# Altium schematic sheet TOP.SchDoc(TOP)
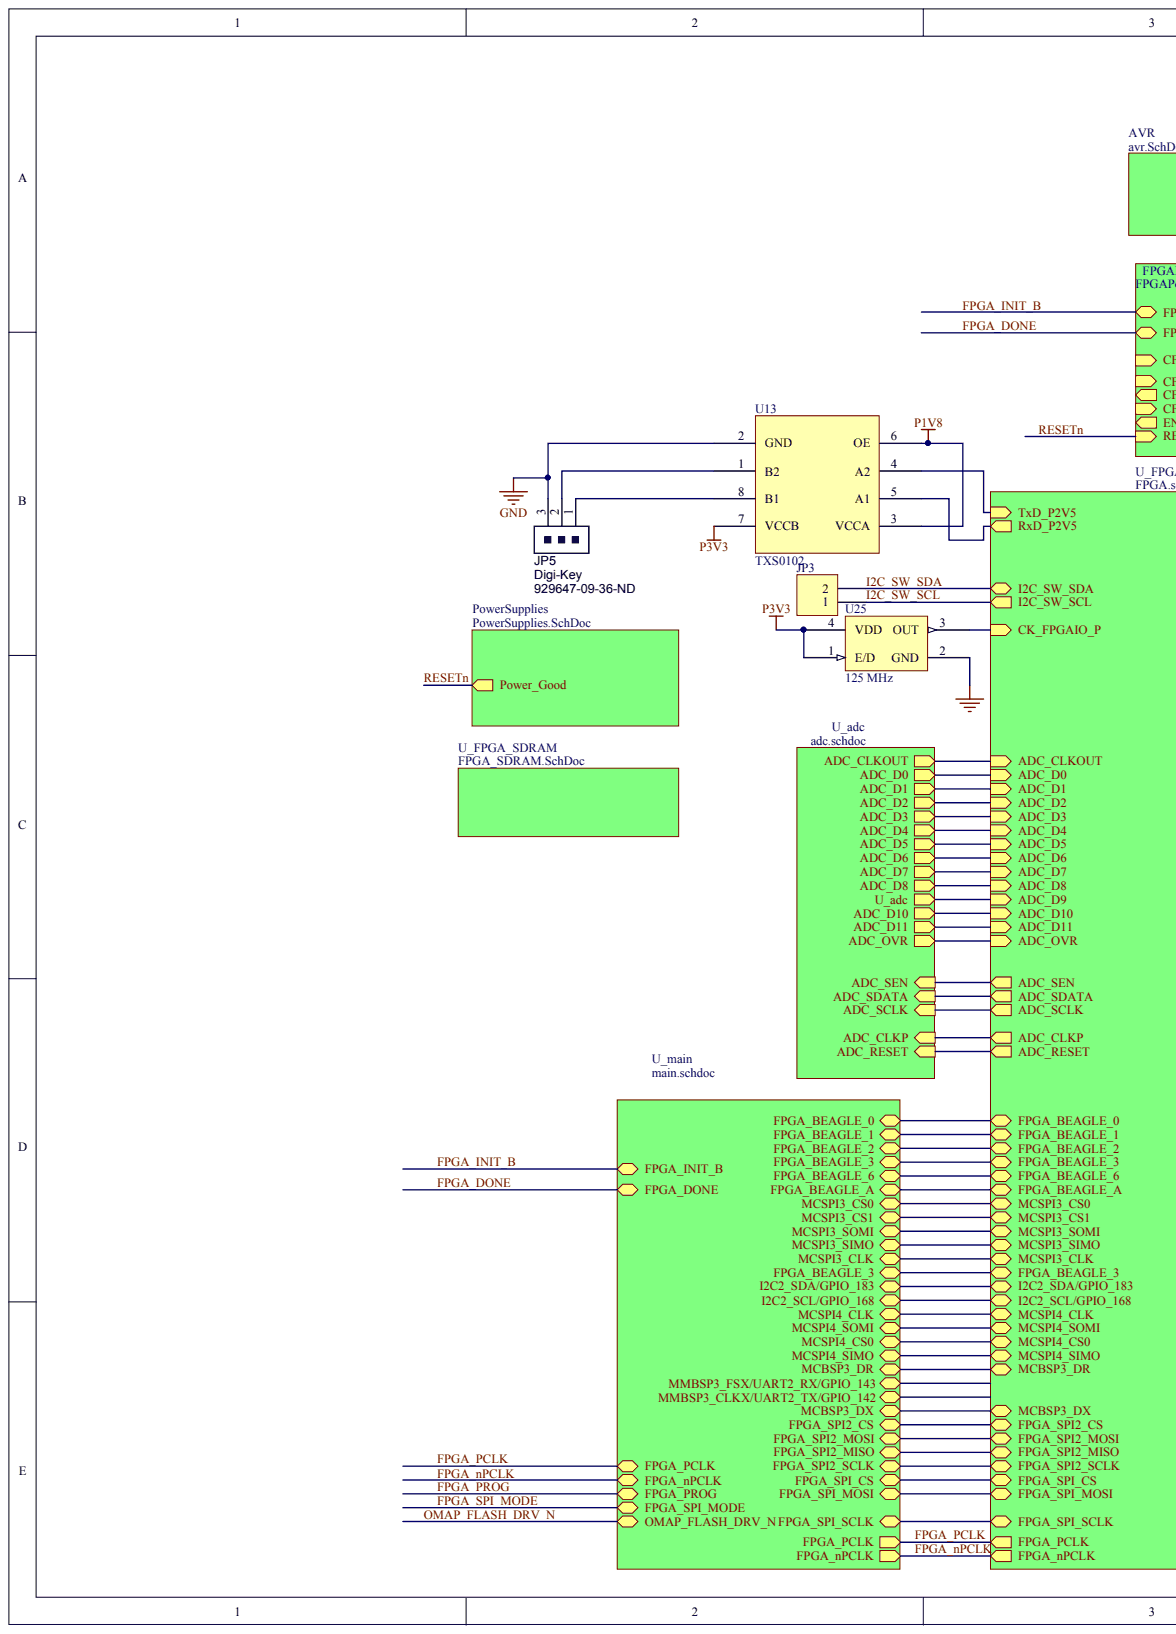
[diagram p0: TOP.SchDoc, left]
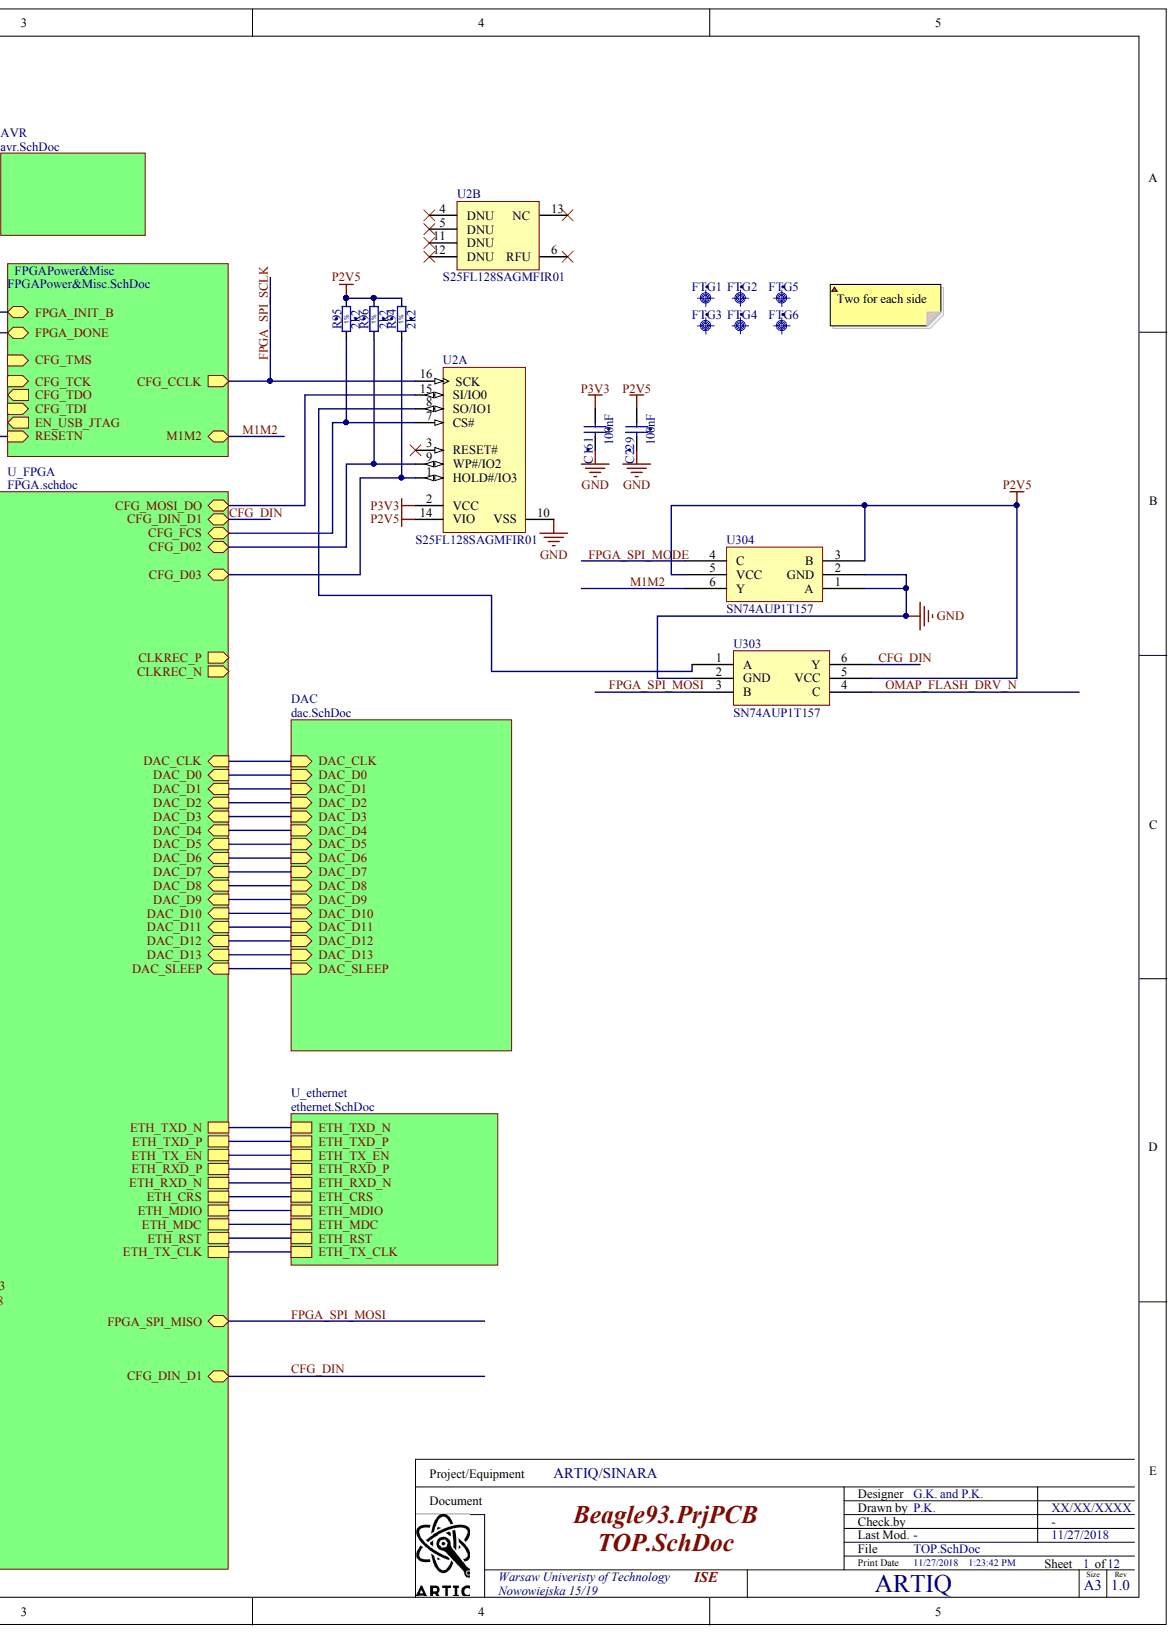
[diagram p1: TOP.SchDoc, right]

## Parts
C161  100nF  [CAPC0603X33N]
C229  100nF  [CAPC0603X33N]
FTG1  Use only in PCB  [FIDUCIAL_TOP_C100-200]  (value not drawn)  (no BOM)
FTG2  Use only in PCB  [FIDUCIAL_TOP_S100-200]  (value not drawn)  (no BOM)
FTG3  Use only in PCB  [FIDUCIAL_TOP_C100-200]  (value not drawn)  (no BOM)
FTG4  Use only in PCB  [FIDUCIAL_TOP_C100-200]  (value not drawn)  (no BOM)
FTG5  Use only in PCB  [FIDUCIAL_TOP_C100-200]  (value not drawn)  (no BOM)
FTG6  Use only in PCB  [FIDUCIAL_TOP_S100-200]  (value not drawn)  (no BOM)
JP3  (no value)  [HDR1X2]
JP5  Supplier 1: Digi-Key  Supplier Part Number 1: 929647-09-36-ND  [1x3POS_HEADER]  (hidden comment: R T G)
R94  2k2  [RESC1005X40N]
R95  2k2  [RESC1005X40N]
R96  2k2  [RESC1005X40N]
U2  S25FL128SAGMFIR01  [SOIC127P1030-16L]  (parts A,B)
U13  TXS0102  [TSSOP65P400X110-8N]
U25  125 MHz  [CSX750FB]
U303  SN74AUP1T157  [SOT363]
U304  SN74AUP1T157  [SOT363]

## Nets
GND: C161.1 C229.1 JP5.3 U2.10(VSS) U13.2(GND) U25.2(GND) U303.2(GND) U304.1(A) U304.2(GND)
P1V8: U13.3(VCCA) U13.6(OE)
P2V5: C229.2 R94.2 R95.2 R96.2 U2.14(VIO) U303.5(VCC) U304.3(B) U304.5(VCC)
P3V3: C161.2 U2.2(VCC) U13.7(VCCB) U25.1(E/D) U25.4(VDD)
CFG_DIN: U303.6(Y)
FPGA_SPI_MODE: U304.4(C)
FPGA_SPI_MOSI: U303.3(B)
FPGA_SPI_SCLK: U2.16(SCK)
I2C_SW_SCL: JP3.1
I2C_SW_SDA: JP3.2
M1M2: U304.6(Y)
OMAP_FLASH_DRV_N: U303.4(C)
NetJP5_1: JP5.1 U13.8(B1)
NetJP5_2: JP5.2 U13.1(B2)
NetR94_1: R94.1 U2.1(HOLD#/IO3)
NetR95_1: R95.1 U2.7(CS#)
NetR96_1: R96.1 U2.9(WP#/IO2)
NetU2_3: U2.3(RESET#)
NetU2_4: U2.4(DNU)
NetU2_5: U2.5(DNU)
NetU2_6: U2.6(RFU)
NetU2_8: U2.8(SO/IO1) U303.1(A)
NetU2_11: U2.11(DNU)
NetU2_12: U2.12(DNU)
NetU2_13: U2.13(NC)
NetU2_15: U2.15(SI/IO0)
NetU13_4: U13.4(A2)
NetU13_5: U13.5(A1)
NetU25_3: U25.3(OUT)
FPGA_DONE:
FPGA_INIT_B:
FPGA_NPCLK:
FPGA_PCLK:
FPGA_PROG:
N00620:
N00621:
N00622:
N00623:
N00624:
N00625:
N00626:
N00627:
N00628:
N00629:
N00630:
N00631:
N00632:
N00633:
N00634:
N00635:
N00636:
N00637:
N00638:
N00639:
N00640:
N00641:
N00642:
N00643:
N00644:
N00645:
N00646:
N00647:
N00648:
N00649:
N00650:
N00651:
N00652:
N00653:
N00654:
N00655:
N00656:
N00657:
N00658:
N00659:
N00660:
N00661:
N00662:
N00663:
N00664:
N00665:
N00666:
N00667:
N00668:
N00669:
N00670:
N00671:
N00672:
N00673:
N00674:
N00675:
N00676:
N00677:
N00678:
N00679:
N00680:
N00681:
N00682:
N00683:
N00684:
N00685:
N00686:
N00687:
N00688:
N00689:
N00690:
N00691:
N00692:
N00693:
N00694:
N00695:
N00696:
N00697:
N00698:
N00699:
N00700:
RESETN:

## Library data of the parts
C161 C229 = CC0201_100NF_6.3V_10%_X5R: Non-polarised capacitor; Manufacturer: GENERIC; Manufacturer1 Part Number: 02016D104KAT2A; Part Description: SMD Multilayer Chip Ceramic Capacitor; Database Table Name: Capacitors SMD; TC: X5R; Tolerance: ±10%; Voltage: 6.3V; Case: 0201; ClassName: Artix; Component Kind: Standard; Component Type: Standard; CreateDate: 03.12.2007; HelpURL: \\cern.ch\dfs\Applications\Altium\Datasheets\CC0201_X5R_AVX.pdf; LatestRevisionDate: 03.12.2007; Library Name: Capacitors.DbLib; Manufacturer1 ComponentHeight: 0.33mm; Manufacturer1 Example: AVX; Manufacturer Part Number: CC0201_100NF_6.3V_10%_X5R; Mounted: Yes; Part Number: CC0201_100NF_6.3V_10%_X5R; Pin Count: 2; Sense: No; SMD: Yes; Socket: No; Status: Not Recommended; Value: 100nF; Author: CERN DEM JLC
FTG1 FTG3-FTG5 = FIDUCIAL_TARGET_C100-200: Fiducial Target; Part Description: Round Fiducial Target, Top Layer, 1.0mm, Top Solder 2.0mm, Keepout =2.0mm; Database Table Name: Pads; Mounted: No; ComponentHeight: 0mm; Component Type: Standard (No BOM); CreateDate: 09.02.2010; Family: Fiducial; HelpURL: Undefined; LatestRevisionDate: 04.04.2012; Library Name: Eletro-mechanical.DbLib; PackageDescription: Round Fiducial Target, Top Layer, 1.0mm, Top Solder 2.0mm, Keepout =2.0mm; Part Number: FIDUCIAL_TARGET_C100-200; Pin Count: 1; Sense: No; SMD: Yes; Socket: No; Status: None; Author: CERN DEM JLC
FTG2 FTG6 = FIDUCIAL_TARGET_S100-200: Fiducial Target; Part Description: Square Fiducial Target, Top Layer, 1.0mm, Top Solder 2.0mm, Keepout =2.0mm; Database Table Name: Pads; Mounted: No; ComponentHeight: 0mm; Component Type: Standard (No BOM); CreateDate: 09.02.2010; Family: Fiducial; HelpURL: Undefined; LatestRevisionDate: 04.04.2012; Library Name: Eletro-mechanical.DbLib; PackageDescription: Square Fiducial Target, Top Layer, 1.0mm, Top Solder 2.0mm, Keepout =2.0mm; Part Number: FIDUCIAL_TARGET_S100-200; Pin Count: 1; Sense: No; SMD: Yes; Socket: No; Status: None; Author: CERN DEM JLC
JP3 = Header 2: Header, 2-Pin; Component Kind: Standard; LatestRevisionDate: 17-Jul-2002; LatestRevisionNote: Re-released for DXP Platform.; Library Name: Miscellaneous Connectors.IntLib; Signal Integrity: Connector; Publisher: Altium Limited
JP5 = CONN_HEADER_1x3P_0.100in: Pin Header, THT, Single-row, 2.54mm pitch; Manufacturer 1: 3M; Manufacturer Part Number 1: 929647-09-36-I; Pitch: 0.100" (2.54mm); QtyOverride: 0.1; SCDType: undef; Value: Single-row, 3 positions; Category: Connectors; Component Kind: Standard; Library Name: PBL - Connectors.SCHLIB; Mounting Type: Through Hole; Package / Case: Pin header; 2.54mm pitch; Terminal Block Type: Header, Male Pins, Single
R94-R96 = R0402_2K2_1%_0.0625W_100PPM: Resistor - 1%; Manufacturer: GENERIC; Manufacturer1 Part Number: 232270672202L; Part Description: General Purpose Thick Film Chip Resistor; Database Table Name: Resistors SMD; PressFit: No; TC: ±100ppm/°C; Case: 0402; ClassName: Artix; Component Kind: Standard; Component Type: Standard; CreateDate: 03.12.2007; HelpURL: \\cern.ch\dfs\Applications\Altium\Datasheets\R0402_Phycomp_RC0402.pdf; LatestRevisionDate: 17.10.2012; Library Name: Resistors.DbLib; Manufacturer1 ComponentHeight: 0.4mm; Manufacturer1 Example: YAGEO PHYCOMP; Manufacturer Part Number: R0402_2K2_1%_0.0625W_100PPM; Mounted: Yes; Part Number: R0402_2K2_1%_0.0625W_100PPM; Pin Count: 2; Power: 0.0625W; Sense: No; SMD: Yes; Socket: No; Status: Not Recommended; Tolerance: ±1%; Value: 2k2; Author: CERN DEM JLC
U2 = CMP-1329-00021-1: MirrorBit Flash Non-Volatile Memory CMOS 3.0 Volt Core with Versatile I/O, Serial Peripheral Interface with Multi-I/O, 128 Mbit, 133 MHz, -40 to 85 degC, 16-Pin SO (SO3016), RoHS, Tube; Manufacturer: Spansion; OTP: 1024 B; Speed: 133 MHz; VCC: 2.7 to 3.6 V; Component Kind: Standard; ComponentLink1Description: Manufacturer URL; ComponentLink1URL: http://www.spansion.com/Pages/Default.aspx; ComponentLink2Description: Datasheet; ComponentLink2URL: http://www.spansion.com/Support/Datasheets/S25FL128S_256S_00.pdf; ComponentLink3Description: Package Specification; ComponentLink3URL: http://www.spansion.com/Support/Datasheets/S25FL128S_256S_00.pdf; DatasheetVersion: Rev. 08; Density: 128 Mbit; Mounting Technology: Surface Mount; PackageDescription: 16-Pin Wide Plastic Small Outline Package, Body 10.3 x 7.5 mm, Pitch 1.27 mm; Packing: Tube; PartNumber: S25FL128SAGMFIR01; RoHS: TRUE; Temperature Range: -40 to 85 degC
U25 = CWX815-100.0M: CWX815-125.0M; Manufacturer: Citizen America; Part No.: CW585TR-ND; Component Kind: Standard; Distributor: Digikey; Manufacturer Part Number: CWX815-100.0M; Library Name: minisdr.schlib
U304 = N74AUP1T157: Component Kind: Standard; Library Name: SN74AUP1T157.SchLib
U13 = TXS0102: Component Kind: Standard; Library Name: beagle_sdr9_1.SCHLIB
U303 = SN74AUP1T157: Component Kind: Standard; Library Name: SN74AUP1T157.SchLib
